# Altium schematic sheet SH_IR_V1.0.SchDoc
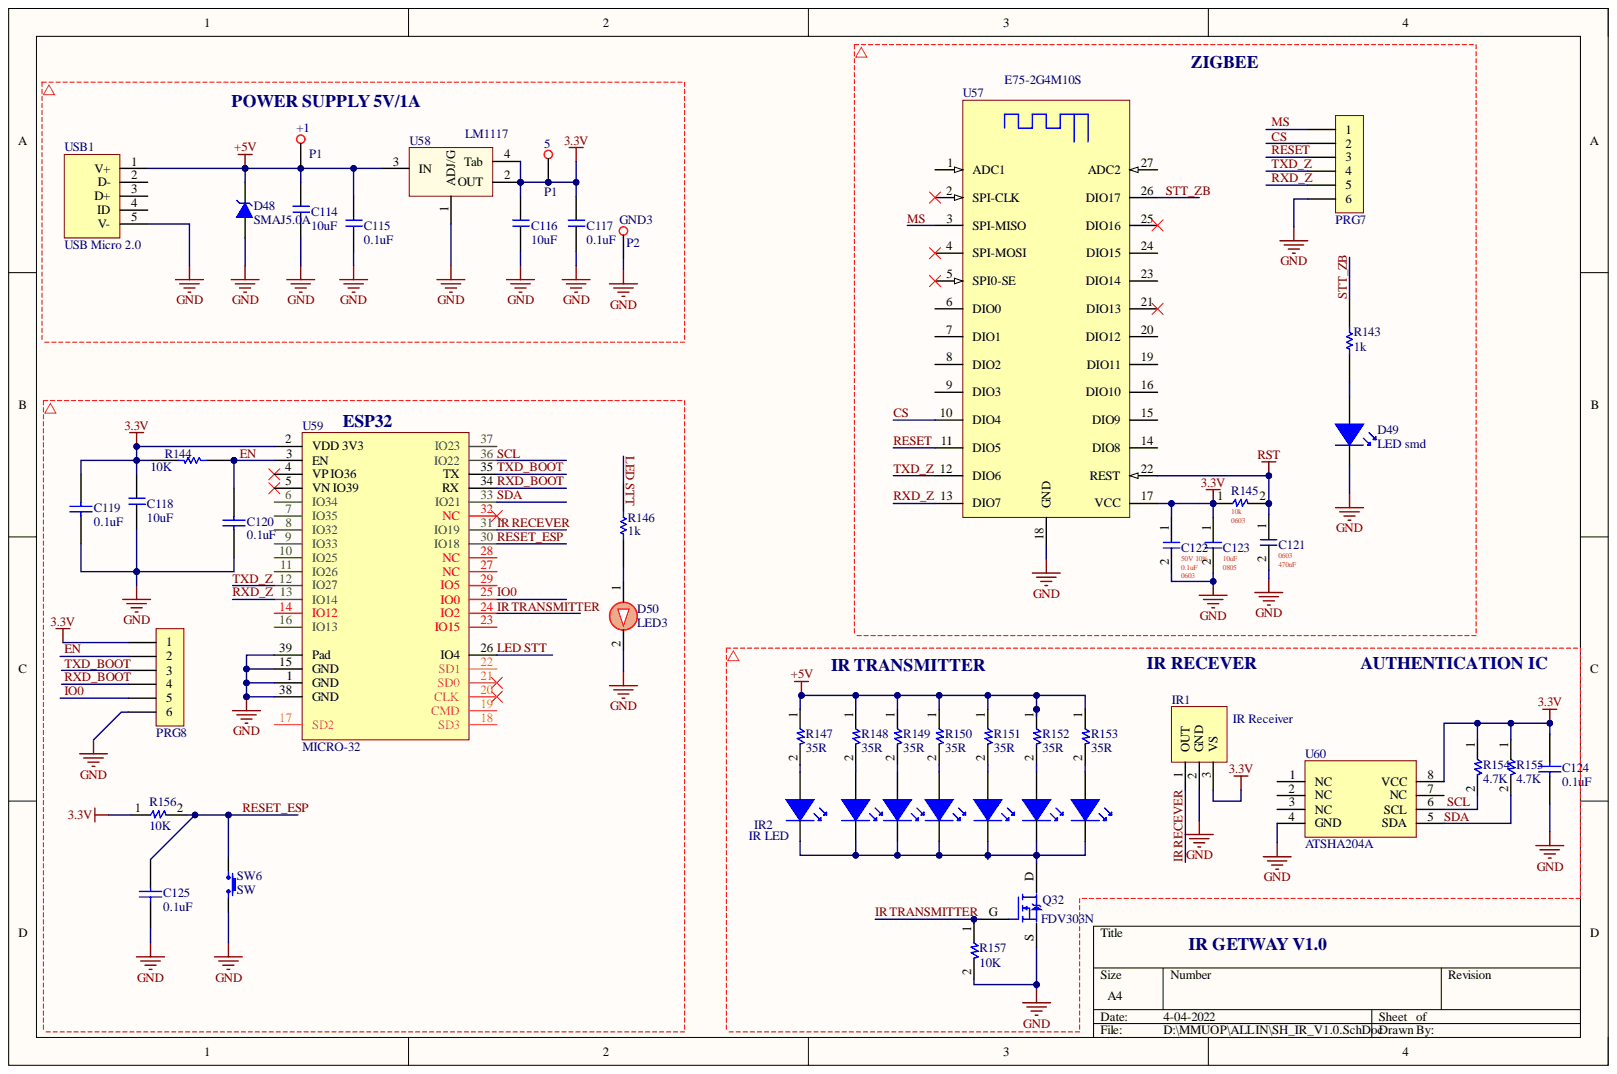
[diagram p0: SH_IR_V1.0.SchDoc]

## Parts
5  P1  [TEXT_POINT]
+1  P1  [TEXT_POINT]
C114  10uF  [0805 C]
C115  0.1uF  [0603 C]
C116  10uF  [0805 C]
C117  0.1uF  [0603 C]
C118  10uF  [0805 C]
C119  0.1uF  [0603 C]
C120  0.1uF  [0603 C]
C121  470nF  FP: 0603  [0603 C]
C122  0.1uF  FP: 0603  VOLTAGE + TOLE: 50V 10%  [0603 C]
C123  10uF  FP: 0805  [0805 C]
C124  0.1uF  [0603 C]
C125  0.1uF  [0603 C]
D48  SMAJ5.0A  [DO214AC]
D49  LED smd  [LED0603_1608]
D50  LED3  [LED3mm]
GND3  P2  [TEXT_POINT]
IR1  IR Receiver  [TSOP1838]
IR2  IR LED  [IR_LED7]
PRG7  P6  [P6 - 1.27MM]  (value not drawn)
PRG8  P6  [P6 - 1.27MM]  (value not drawn)
Q32  FDV303N  [SOT23-3]
R143  1k  [0603 R]
R144  10K  [0603 R]
R145  10k  FP: 0603  [0603 R]
R146  1k  [0603 R]
R147  35R  [0603 R]
R148  35R  [0603 R]
R149  35R  [0603 R]
R150  35R  [0603 R]
R151  35R  [0603 R]
R152  35R  [0603 R]
R153  35R  [0603 R]
R154  4.7K  [0603 R]
R155  4.7K  [0603 R]
R156  10K  [0603 R]
R157  10K  [0603 R]
SW6  SW  [BUTTON 4P]
U57  E75-2G4M10S  [NXP-E75-10S]
U58  LM1117  [SOT223-4N]
U59  MICRO-32  [ESP MICRO 32]
U60  ATSHA204A  [SOIC8]
USB1  USB Micro 2.0  [USB Micro 2.0]

## Nets
3.3V: 5.1 C116.2 C117.2 C118.2 C119.2 C122.1 C123.1 C124.2 IR1.3 PRG8.1 R144.2 R145.1 R154.1 R155.1 R156.1 U57.17(VCC) U58.2(OUT) U58.4(Tab) U59.2(VDD_3V3) U60.8(VCC)
+5V: C114.2 C115.2 D48.2 R147.1 R148.1 R149.1 R150.1 R151.1 R152.1 R153.1 U58.3(IN) USB1.1(V+)
GND: C114.1 C115.1 C116.1 C117.1 C118.1 C119.1 C120.1 C121.2 C122.2 C123.2 C124.1 C125.1 D48.1 D49.2 D50.2 GND3.1 IR1.2 PRG7.6 PRG8.6 Q32.S R157.2 SW6.2 U57.18(GND) U58.1(ADJ/G) U59.1(GND) U59.15(GND) U59.38(GND) U59.39(Pad) U60.4(GND) USB1.5(V-)
RST: C121.1 R145.2 U57.22(REST)
CS: PRG7.2 U57.10(DIO4)
EN: C120.2 PRG8.2 R144.1 U59.3(EN)
IO0: PRG8.5 U59.25(IO0)
IR RECEVER: IR1.1 U59.31(IO19)
IR TRANSMITTER: Q32.G R157.1 U59.24(IO2)
LED STT: R146.1 U59.26(IO4)
MS: PRG7.1 U57.3(SPI-MISO)
RESET: PRG7.3 U57.11(DIO5)
RESET_ESP: C125.2 R156.2 SW6.1 U59.30(IO18)
RXD_BOOT: PRG8.4 U59.34(RX)
RXD_Z: PRG7.5 U57.13(DIO7) U59.13(IO14)
SCL: R154.2 U59.36(IO22) U60.6(SCL)
SDA: R155.2 U59.33(IO21) U60.5(SDA)
STT_ZB: R143.1 U57.26(DIO17)
TXD_BOOT: PRG8.3 U59.35(TX)
TXD_Z: PRG7.4 U57.12(DIO6) U59.12(IO27)
NetD49_1: D49.1 R143.2
NetD50_1: D50.1 R146.2
NetIR2_1: IR2.1 R147.2
NetIR2_2: IR2.2 R148.2
NetIR2_3: IR2.3 R149.2
NetIR2_4: IR2.4 R150.2
NetIR2_5: IR2.5 R151.2
NetIR2_6: IR2.6 R152.2
NetIR2_7: IR2.7 R153.2
NetIR2_K: IR2.K Q32.D

Not connected: +1 1; U57 1(ADC1) 2(SPI-CLK) 4(SPI-MOSI) 5(SPI0-SE) 6(DIO0) 7(DIO1) 8(DIO2) 9(DIO3) 14(DIO8) 15(DIO9) 16(DIO10) 19(DIO11) 20(DIO12) 21(DIO13) 23(DIO14) 24(DIO15) 25(DIO16) 27(ADC2); U59 4(VP_IO36) 5(VN_IO39) 6(IO34) 7(IO35) 8(IO32) 9(IO33) 10(IO25) 11(IO26) 14(IO12) 16(IO13) 17(SD2) 18(SD3) 19(CMD) 20(CLK) 21(SD0) 22(SD1) 23(IO15) 27(NC) 28(NC) 29(IO5) 32(NC) 37(IO23); U60 1(NC) 2(NC) 3(NC) 7(NC); USB1 2(D-) 3(D+) 4(ID)

## Library data of the parts
C114-C118 = CAP NOP: Component Kind: Standard; Library Name: Kienlib.SchLib
C119 C120 C124 C125 = CAPACITOR NON POL: Component Kind: Standard; Library Name: Library V1.0.IntLib
C121 = 0603 470nF CER: CAP CER 470nF 50V 10% 0603 SMD; VOLTAGE + TOLE: 50V 10%; Component Kind: Standard; ComponentLink1Description: New link; DATE: 04-Mar-21; Library Name: Capacitor.SchLib; PACKAGE: SMD; VALUE: 470nF; CREATOR: MMUOP
C122 = 0603 0.1uF CER: CAP CER 0.1uF 50V 10% 0603 SMD; Component Kind: Standard; ComponentLink1Description: New link; DATE: 04-Mar-21; Library Name: Capacitor.SchLib; PACKAGE: SMD; VALUE: 0.1uF; CREATOR: MMUOP
C123 = 0805 10uF CER: CAP CER 10uF 50V 10% 0805 SMD; VOLTAGE + TOLE: 50V 10%; Component Kind: Standard; ComponentLink1Description: New link; DATE: 04-Mar-21; Library Name: Capacitor.SchLib; PACKAGE: SMD; VALUE: 10uF; CREATOR: MMUOP
D48 = Diode Zener: Editor: MMUOP; Component Kind: Standard; Library Name: Kienlib.SchLib
D50 = LED 3mm: Component Kind: Standard; Description: LED 3mm; Library Name: My Library.SchLib
GND3 = P1: Component Kind: Standard; Library Name: Kienlib.SchLib
Q32 = MOSFET - N: Component Kind: Standard; Library Name: Kienlib.SchLib
R143 R144 R146 = RESISTOR: Component Kind: Standard; Library Name: Library V1.0.IntLib
R145 = 0603 10k 1%: 10k 1% 0603 SMD; PN: C98220; Component Kind: Standard; ComponentLink1Description: New link; DATE: 04-Mar-21; Library Name: Res.SchLib; PACKAGE: SMD; VALUE: 10k; VOLTAGE + TOLE: 1%; CREATOR: MMUOP
R147-R153 = Res SMD: Manufacture P/N: C0603CK; Component Kind: Standard; Library Name: Kienlib.SchLib
R154-R157 = Res SMD: Component Kind: Standard; Library Name: Kienlib.SchLib
U57 = ebyte - E75-2G4M10S: Component Kind: Standard; Description: E75-2G4M10S; Library Name: Kienlib.SchLib
U58 = LM1117: 3v3; Value: LM317; Component Kind: Standard; Library Name: VioletofSun.SchLib
U59 = ESP MICRO-32: Component Kind: Standard; Description: MICRO-32; Library Name: Kienlib.SchLib
U60 = ATSHA204-A: Component Kind: Standard; Library Name: Kienlib.SchLib
5 +1 = P1: Component Kind: Standard; Library Name: Kienlib.SchLib
D49 = LED smd: Component Kind: Standard; Library Name: Kienlib.SchLib
IR1 = IR Receiver: Component Kind: Standard; Library Name: Kienlib.SchLib
IR2 = IR LED: Component Kind: Standard; Library Name: Kienlib.SchLib
PRG7 PRG8 = P6: Component Kind: Standard; Library Name: Kienlib.SchLib
SW6 = SW: Component Kind: Standard; Library Name: Kienlib.SchLib
USB1 = USB Micro 2.0: Component Kind: Standard; Library Name: Kienlib.SchLib
